# Altium schematic sheet Esp32_USB.SchDoc
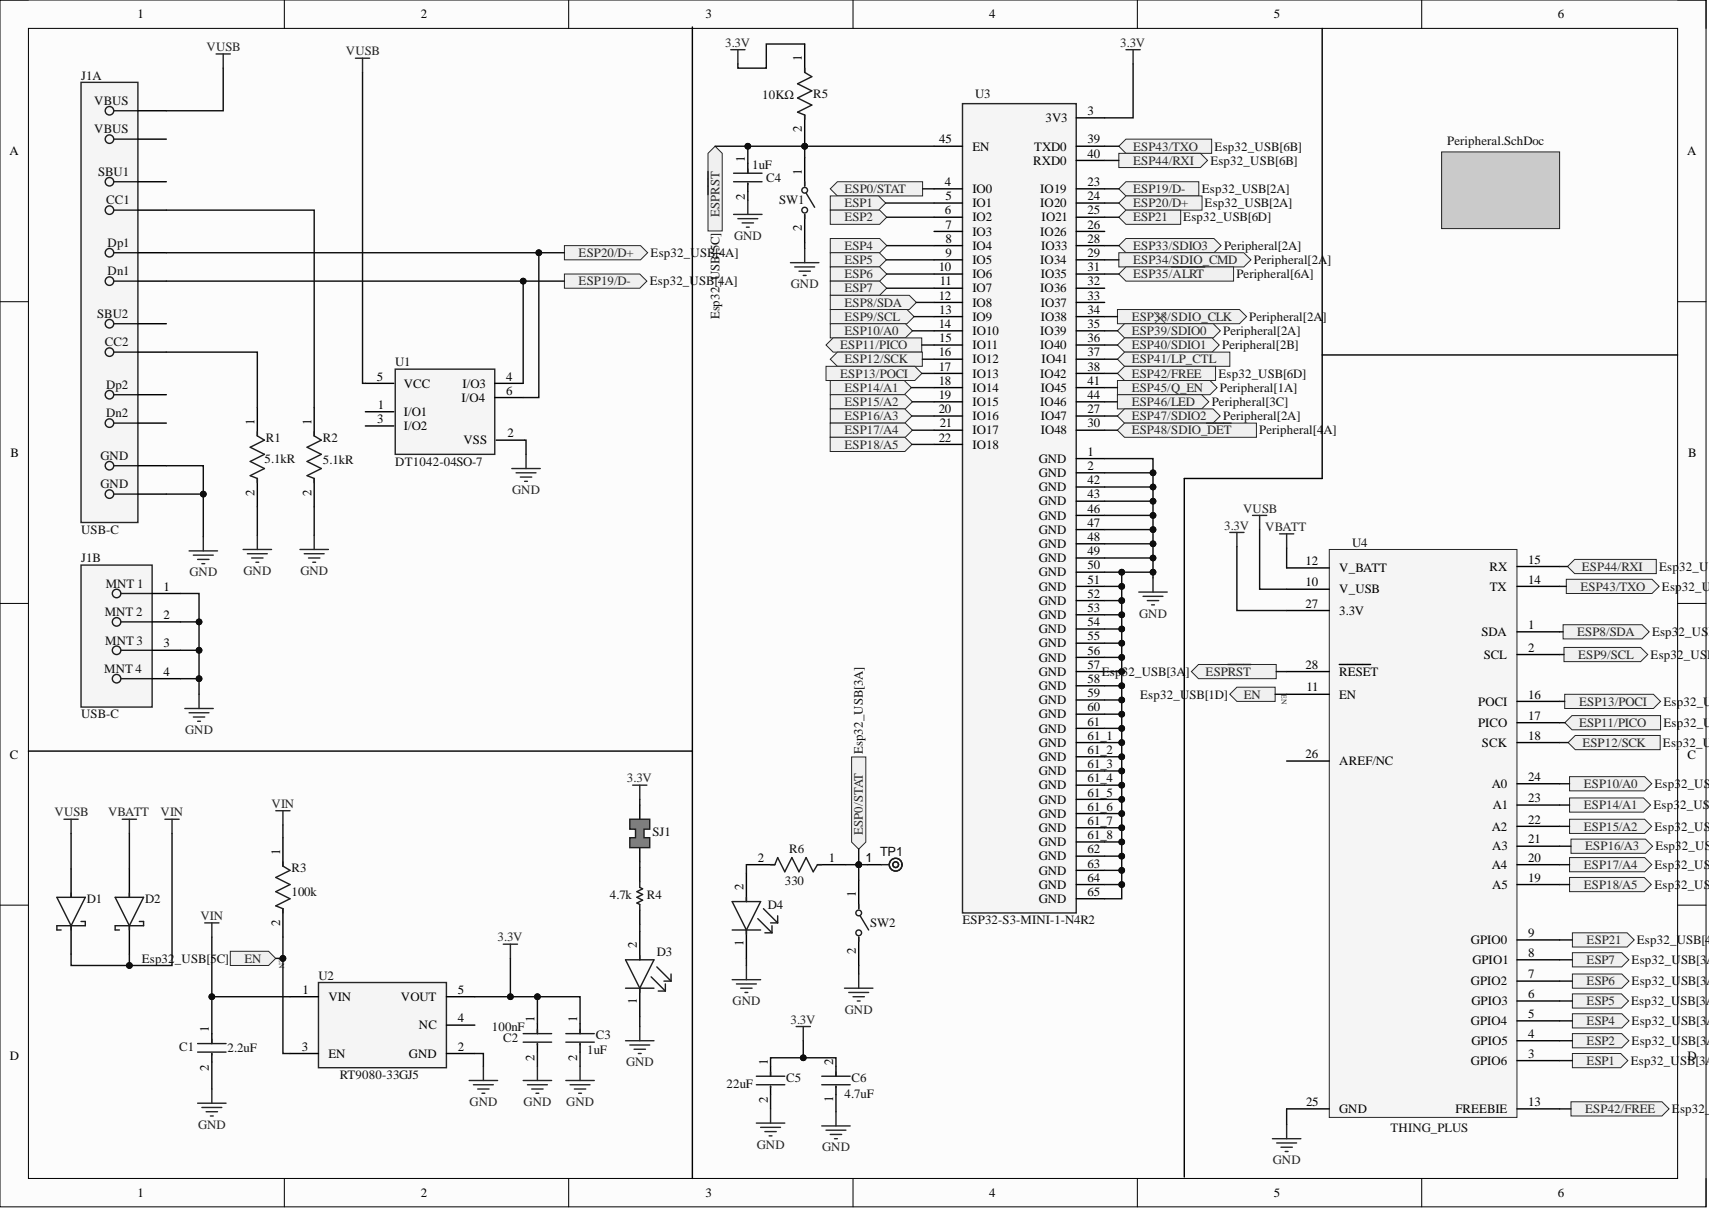
[diagram p0: Esp32_USB.SchDoc]

## Parts
C1  Capacitance: 2.2uF  [FP-GRM155-0_1-IPC_C]  (hidden comment: GRM155C81A225KE44D)
C2  Capacitance: 100nF  [FP-GRM033-0_03-IPC_B]  (hidden comment: GRM033C70J104KE14D)
C3  Capacitance: 1uF  [FP-GRM155-0_05-IPC_C]  (hidden comment: GRM155R61C105KA12D)
C4  Capacitance: 1uF  [FP-0402-L_1_0_0_05-W_0_5-IPC_B]  (hidden comment: EMK105BJ105KVHF)
C5  Capacitance: 22uF  [FP-0603-L_1_6_0_2-W_0_8_0-IPC_B]  (hidden comment: JMK107BC6226MA-T)
C6  Capacitance: 4.7uF  [FP-0402-L_1_0_0_15-W_0_5-IPC_C]  (hidden comment: JMK105BC6475MV-F)
D1  BAT60AE6327HTSA1  [FP-PG-SOD323-2-IPC_C]  (value not drawn)
D2  BAT60AE6327HTSA1  [FP-PG-SOD323-2-IPC_C]  (value not drawn)
D3  LTST-C191KRKT  [FP-LTST-C191KRKT-MFG]  (value not drawn)
D4  LTST-C194TGKT  [FP-LTST-C194TGKT-MFG]  (value not drawn)
J1  USB-C  [FP-2171790001-MFG]  (parts A,B)
R1  Resistance: 5.1kR  [FP-AC0402-IPC_C]  (hidden comment: AC0402JR-075K1L)
R2  Resistance: 5.1kR  [FP-AC0402-IPC_C]  (hidden comment: AC0402JR-075K1L)
R3  Resistance: 100k  [FP-CRCW0402-C-e3-MFG]  (hidden comment: CRCW0402100KFKEDC)
R4  Resistance: 4.7k  [RESC1005X40X25LL05T10]  (hidden comment: CRCW04024K70FKEDHP)
R5  value 10KΩ  Resistance: 10KΩ  [FP-RT0201-MFG]  (hidden comment: RT0201FRE0710KL)
R6  AC0603FR-07330RL  [FP-AC0603-MFG]  (value not drawn)
SJ1  Solder Jumper  [Solder Jumper-Footprint-1]  (value not drawn)
SW1  FSM2JART  [FP-FSM2JART-MFG]  (value not drawn)
SW2  FSM2JART  [FP-FSM2JART-MFG]  (value not drawn)
TP1  TPAD6R  [TP06R]  (value not drawn)  (no BOM)
U1  DT1042-04SO-7  [FP-SOT26_SC74R-MFG]
U2  RT9080-33GJ5  [FP-RT9080-33GJ5-IPC_C]
U3  ESP32-S3-MINI-1-N4R2  [XCVR_ESP32-S3-MINI-1-N4R2]
U4  THING_PLUS  (no BOM)

## Ports
EN, ESP0/STAT, ESP1, ESP2, ESP4, ESP5, ESP6, ESP7, ESP8/SDA, ESP9/SCL, ESP10/A0, ESP11/PICO, ESP12/SCK, ESP13/POCI, ESP14/A1, ESP15/A2, ESP16/A3, ESP17/A4, ESP18/A5, ESP19/D-, ESP20/D+, ESP21, ESP33/SDIO3, ESP34/SDIO_CMD, ESP35/~{ALRT}, ESP38/SDIO_CLK, ESP39/SDIO0, ESP40/SDIO1, ESP41/LP_CTL, ESP42/FREE, ESP43/TXO, ESP44/RXI, ESP45/Q_EN, ESP46/LED, ESP47/SDIO2, ESP48/SDIO_~{DET}, ESP~{RST}

## Nets
3.3V: C2.1 C3.1 C5.1 C6.2 R5.1 SJ1.1 U2.5(VOUT) U3.3(3V3) U4.27(3.3V)
GND: C1.2 C2.2 C3.2 C4.2 C5.2 C6.1 D3.1 D4.1 J1.1 J1.2 J1.3 J1.4 J1.A1-B12 J1.B1-A12 R1.2 R2.2 SW1.2 SW2.2 U1.2(VSS) U2.2(GND) U3.1(GND) U3.2(GND) U3.42(GND) U3.43(GND) U3.46(GND) U3.47(GND) U3.48(GND) U3.49(GND) U3.50(GND) U3.51(GND) U3.52(GND) U3.53(GND) U3.54(GND) U3.55(GND) U3.56(GND) U3.57(GND) U3.58(GND) U3.59(GND) U3.60(GND) U3.61(GND) U3.61_1(GND) U3.61_2(GND) U3.61_3(GND) U3.61_4(GND) U3.61_5(GND) U3.61_6(GND) U3.61_7(GND) U3.61_8(GND) U3.62(GND) U3.63(GND) U3.64(GND) U3.65(GND) U4.25(GND)
VBATT: D2.2 U4.12(V_BATT)
VIN: C1.1 D1.1 D2.1 R3.1 U2.1(VIN)
VUSB: D1.2 J1.A4-B9 U1.5(VCC) U4.10(V_USB)
EN: R3.2 U2.3(EN) U4.11(EN) ; port EN
ESP0/STAT: R6.1 SW2.1 TP1.1 U3.4(IO0) ; port ESP0/STAT
ESP1: U3.5(IO1) U4.3(GPIO6) ; port ESP1
ESP2: U3.6(IO2) U4.4(GPIO5) ; port ESP2
ESP4: U3.8(IO4) U4.5(GPIO4) ; port ESP4
ESP5: U3.9(IO5) U4.6(GPIO3) ; port ESP5
ESP6: U3.10(IO6) U4.7(GPIO2) ; port ESP6
ESP7: U3.11(IO7) U4.8(GPIO1) ; port ESP7
ESP8/SDA: U3.12(IO8) U4.1(SDA) ; port ESP8/SDA
ESP9/SCL: U3.13(IO9) U4.2(SCL) ; port ESP9/SCL
ESP10/A0: U3.14(IO10) U4.24(A0) ; port ESP10/A0
ESP11/PICO: U3.15(IO11) U4.17(PICO) ; port ESP11/PICO
ESP12/SCK: U3.16(IO12) U4.18(SCK) ; port ESP12/SCK
ESP13/POCI: U3.17(IO13) U4.16(POCI) ; port ESP13/POCI
ESP14/A1: U3.18(IO14) U4.23(A1) ; port ESP14/A1
ESP15/A2: U3.19(IO15) U4.22(A2) ; port ESP15/A2
ESP16/A3: U3.20(IO16) U4.21(A3) ; port ESP16/A3
ESP17/A4: U3.21(IO17) U4.20(A4) ; port ESP17/A4
ESP18/A5: U3.22(IO18) U4.19(A5) ; port ESP18/A5
ESP19/D-: J1.A7 U1.4(I/O3) U3.23(IO19) ; port ESP19/D-
ESP20/D+: J1.A6 U1.6(I/O4) U3.24(IO20) ; port ESP20/D+
ESP21: U3.25(IO21) U4.9(GPIO0) ; port ESP21
ESP33/SDIO3: U3.28(IO33) ; port ESP33/SDIO3
ESP34/SDIO_CMD: U3.29(IO34) ; port ESP34/SDIO_CMD
ESP35/~{ALRT}: U3.31(IO35) ; port ESP35/~{ALRT}
ESP38/SDIO_CLK: U3.34(IO38) ; port ESP38/SDIO_CLK
ESP39/SDIO0: U3.35(IO39) ; port ESP39/SDIO0
ESP40/SDIO1: U3.36(IO40) ; port ESP40/SDIO1
ESP41/LP_CTL: U3.37(IO41) ; port ESP41/LP_CTL
ESP42/FREE: U3.38(IO42) U4.13(FREEBIE) ; port ESP42/FREE
ESP43/TXO: U3.39(TXD0) U4.14(TX) ; port ESP43/TXO
ESP44/RXI: U3.40(RXD0) U4.15(RX) ; port ESP44/RXI
ESP45/Q_EN: U3.41(IO45) ; port ESP45/Q_EN
ESP46/LED: U3.44(IO46) ; port ESP46/LED
ESP47/SDIO2: U3.27(IO47) ; port ESP47/SDIO2
ESP48/SDIO_~{DET}: U3.30(IO48) ; port ESP48/SDIO_~{DET}
ESP~{RST}: C4.1 R5.2 SW1.1 U3.45(EN) U4.28(RESET) ; port ESP~{RST}
NetD3_2: D3.2 R4.2
NetD4_2: D4.2 R6.2
NetJ1_A5: J1.A5 R2.1
NetJ1_B5: J1.B5 R1.1
NetR4_1: R4.1 SJ1.2

Not connected: J1 A8 B4-A9 B6 B7 B8; U1 1(I/O1) 3(I/O2); U2 4(NC); U3 7(IO3) 26(IO26) 32(IO36) 33(IO37); U4 26(AREF/NC)

## Library data of the parts
C1 = CMP-06035-004415-1: Chip Multilayer Ceramic Capacitors for General Purpose, 0402, 2.2uF, X6S, 22%, 10%, 10V; Case Code (Imperial): 0402; Case Code (Metric): 1005; Case/Package: 0402; Component Kind: Standard; Dielectric: X6S; Height: 0.5mm; Length: 0.039inch; Library Name: Altium Content Vault; Max Operating Temperature: 105°C; Min Operating Temperature: -55°C; Mount: Surface Mount; Package Quantity: 10000; Packaging: Tape and Reel; Termination: SMD/SMT; Thickness: 0.022inch; Tolerance: 10%; Voltage Rating: 10V; Voltage Rating (DC): 10V; Weight: 0.000212oz; Width: 0.02inch
C2 = CMP-06035-000516-1: Chip Multilayer Ceramic Capacitors for General Purpose, 0201, 0.10uF, X7S, 22%, 10%, 6.3V; Component Kind: Standard; Dielectric: X7S; Length: 600um; Library Name: Altium Content Vault; Thickness: 300um; Tolerance: 10%; Voltage Rating (DC): 6.3V; Width: 300um
C3 = CMP-2008-04573-2: Chip Multilayer Ceramic Capacitors for General Purpose, 0402, 1.0uF, X5R, 15%, 10%, 16V; Case Code (Imperial): 0402; Case Code (Metric): 1005; Case/Package: 0402; Component Kind: Standard; Depth: 0.5mm; Dielectric: X5R; Height: 0.5mm; Lead Free: Lead Free; Length: 1mm; Library Name: Altium Content Vault; Material: Ceramic; Max Operating Temperature: 85°C; Min Operating Temperature: -55°C; Mount: Surface Mount; Packaging: Tape and Reel; Radiation Hardening: No; REACH SVHC: No SVHC; Thickness: 0.022inch; Tolerance: 10%; Voltage: 16V; Voltage Rating: 16V; Voltage Rating (DC): 16V; Width: 0.02inch
C4 = CMP-14477-000084-2: None; Dielectric: X5R; Tolerance: 10%; Voltage Rating: 16V; Case Code (Imperial): 0402; Case Code (Metric): 1005; Case/Package: 0402; Component Kind: Standard; Halogen Free: Halogen Free; Height: 0.5mm; Lead Free: Lead Free; Length: 0.039inch; Library Name: Altium Content Vault; Max Operating Temperature: 85°C; Min Operating Temperature: -55°C; Mount: Surface Mount; Package Quantity: 10000; Packaging: Tape and Reel; Ratings: AEC-Q200; RoHS Compliant: Yes; Termination: SMD/SMT; Thickness: 0.022inch; Voltage Rating (DC): 16V; Weight: 2.3E-05oz; Width: 0.5mm
C5 = CMP-14477-000021-3: Multilayer Ceramic Capacitor 22uF 6.3V X6S 20% SMD 0603 T/R; Case Code (Imperial): 0603; Case Code (Metric): 1608; Case/Package: 0603; Component Kind: Standard; Library Name: Altium Content Vault; Max Operating Temperature: 105°C; Min Operating Temperature: -55°C; Mount: Surface Mount; Packaging: Tape and Reel; RoHS Compliant: Yes; Tolerance: 20%; Voltage Rating: 6.3V
C6 = CMP-14477-001053-2: None; Tolerance: 20%; Voltage Rating: 6.3V; Case Code (Imperial): 0402; Case Code (Metric): 1005; Case/Package: 0402; Component Kind: Standard; Lead Free: Lead Free; Library Name: Altium Content Vault; Max Operating Temperature: 105°C; Min Operating Temperature: -55°C; Mount: Surface Mount; Packaging: Tape and Reel; RoHS Compliant: Yes
D1 D2 = CMP-32890-000004-1: DIODE SCHOTTKY 10V 3A SOD323-2; Element Configuration: Single; Forward Voltage: 370mV; Peak Non-Repetitive Surge Current: 5A; Polarity: Standard; Average Rectified Current: 3A; Case/Package: SOD-323-2; Component Kind: Standard; Contact Plating: Tin; Current Rating: 3A; Forward Current: 3A; Halogen Free: Not Halogen Free; Lead Free: Lead Free; Library Name: Altium Content Vault; Max Operating Temperature: 85°C; Max Repetitive Reverse Voltage (Vrrm): 10V; Max Reverse Voltage (DC): 10V; Min Operating Temperature: -55°C; Mount: Surface Mount; Number of Pins: 2; Output Current: 3A; Packaging: Tape and Reel; Radiation Hardening: No; REACH SVHC: No SVHC; Voltage Rating (DC): 10V
D3 = CMP-2000-05199-2: NexarPartId: 549908; Color: Red; Current: 2A; Dominant Wavelength: 631nm; Forward Current: 20mA; Forward Voltage: 2V; Lens Color: Colorless; Lens Style: Clear; Luminous Intensity: 54mcd; Number of Elements: 1; Power Dissipation: 75mW; Reverse Voltage: 5V; Viewing Angle: 130°; Wavelength: 639nm; Case Code (Imperial): 0603; Case Code (Metric): 1608; Case/Package: 0603; Component Kind: Standard; Depth: 800µm; Height: 550µm; Illumination Color: Red; Lead Free: Lead Free; Length: 1.6mm; Lens Transparency: Clear; Library Name: Altium Content Vault; Max Operating Temperature: 85°C; Min Operating Temperature: -55°C; Mount: Surface Mount; Number of LEDs: 1; Number of Pins: 2; Packaging: Tape and Reel; Power Rating: 75mW; Radiation Hardening: No; RoHS: Compliant; Schedule B: 8541402000, 8541402000; Test Current: 20mA; Voltage: 2V; Width: 800µm
D4 = CMP-22017-000031-1: LED GREEN CLEAR SMD; Dominant Wavelength: 525nm; Forward Current: 5mA; Forward Voltage: 3.2V; Lens Color: Colorless; Lens Style: Diffused; Luminous Intensity: 260.5mcd; Number of LEDs: 1; Test Current: 20mA; Viewing Angle: 130°; Case Code (Imperial): 0603; Case Code (Metric): 1608; Case/Package: 0603; Color: Green; Component Kind: Standard; Height: 0.35mm; Illumination Color: Green; Lead Free: Lead Free; Length: 1.6mm; Lens Transparency: Clear; Library Name: Altium Content Vault; Max Operating Temperature: 80°C; Min Operating Temperature: -20°C; Mount: Surface Mount; Number of Pins: 2; Package Quantity: 3000; Packaging: Tape and Reel; Radiation Hardening: No; Width: 0.8mm
J1 = CMP-04767-000028-2: CONN RCP USB2.0 TYP C 24P SMD RA; NexarPartId: 117190526; Current Rating: 1.25A; Gender: Receptacle; Number of Contacts: 16; Voltage Rating: 30V; Component Kind: Standard; Contact Material: Gold, Nickel; Height: 3.36mm; Library Name: Altium Content Vault; Max Operating Temperature: 85°C; Min Operating Temperature: -40°C; USB Standard: USB 2.0
R1 R2 = CMP-03412-038544-1: RES 5.1kΩ ±5% 0.063W 0402; Max Power Dissipation: 63mW; Power Rating: 62.5mW; Temperature Coefficient: 100ppm/°C; Voltage Rating: 50V; Case Code (Imperial): 0402; Case Code (Metric): 1005; Case/Package: 0402; Component Kind: Standard; Features: Moisture Resistant; Height: 0.015inch; Length: 0.039inch; Library Name: Altium Content Vault; Max Operating Temperature: 155°C; Min Operating Temperature: -55°C; Number of Terminations: 2; Packaging: Tape and Reel; Resistor Type: Thick Film; RoHS Compliant: Yes; Series: AC; Termination: SMD/SMT; Tolerance: 5%; Weight: 2.3E-05oz; Width: 0.5mm
R3 = CMP-02407-010951-1: RES 100K OHM 1% 1/16W 0402; Power Rating: 62.5mW; Temperature Coefficient: 100ppm/°C; Case/Package: SMD/SMT; Component Kind: Standard; Contact Plating: Tin; Lead Free: Lead Free; Length: 1mm; Library Name: Altium Content Vault; Max Operating Temperature: 155°C; Min Operating Temperature: -55°C; Mount: Surface Mount; Resistor Type: Thick Film; RoHS Compliant: Yes; Termination: Wraparound; Tolerance: 1%
R4 = CMP-2002-03050-1: Manufacturer: Vishay Dale; Component Kind: Standard; Library Name: Altium Content Vault; Part Number: CRCW04024K70FKEDHP
R5 = CMP-03412-013100-1: RES SMD 10K OHM 1% 1/20W 0201; Manufacturer: Yageo; Power Rating: 0.05W; Temperature Coefficient: 50 ppm/°C; Tolerance: 0.01; Voltage Rating: 25V; Automotive: No; Category: Res; Component Kind: Standard; ComponentLink2Description: Datasheet; ComponentLink2URL: http://www.yageo.com/documents/recent/PYu-RT_1-to-0.01_RoHS_L_9.pdf; Composition: Thin Film; Device Class L1: Passive Components; Device Class L2: Resistors; Device Class L3: Chip SMD Resistors; Digikey Description: RES SMD 10K OHM 1% 1/20W 0201; Footprint Url: http://www.yageo.com/exep/pages/download/literatures/PYu-R_Mount_10.pdf; Height: 0.26mm; Ipc Land Pattern Name: RESC6030X23; Lead Free: Yes; Library Name: Altium Content Vault; Manufacturer Part Number: RT0201FRE0710KL; Mouser Description: Res Thin Film 0201 10K Ohm 1% 1/20W ±50ppm/°C Molded SMD Paper T/R; Octopart: https://octopart.com/rt0201fre0710kl-yageo-71392129; Package: RT0201; RoHS: TRUE; Series: RT; Temperature: -55°C to +125°C; Temperature Range High: +125°C; Temperature Range Low: -55°C
R6 = CMP-03412-003137-2: RES 330Ω 1.00% 0.1W 0603; Max Power Dissipation: 100mW; Resistance: 330; Temperature Coefficient: 100ppm/°C; Tolerance: 1%; Voltage Rating: 50V; Case Code (Imperial): 0603; Case Code (Metric): 1608; Case/Package: 0603; Component Kind: Standard; Construction: Rectangular; Depth: 0.8mm; Features: Moisture Resistant; Height: 0.45mm; Length: 1.6mm; Library Name: Altium Content Vault; Max Operating Temperature: 155°C; Military Standard: Not; Min Operating Temperature: -55°C; Number of Terminations: 2; Packaging: Tape and Reel; Power Rating: 100mW; Ratings: AEC-Q200; Resistor Type: Thick Film; RoHS Compliant: Yes; Series: AC; Termination: SMD/SMT; Width: 0.8mm
SJ1 = CMP-00030-00001-3: 2 pad Solder Jumper; Case/Package: 1206; Component Kind: Standard; Max Operating Temperature: 155°C; Min Operating Temperature: -55°C; Mounting Technology: Surface Mount; Pins: 2; Library Name: Shuvendu Das
SW1 SW2 = CMP-03407-000009-1: SWITCH TACTILE SPST-NO 0.05A 12V; Actuator Length: 0.7mm; Actuator Material: Resin; Actuator Type: Standard; Contact Current Rating: 50mA; Contact Resistance: 100mR; Electrical Life: 100000Cycles; Illumination Type: Non-Illuminated; Operating Force: 260gf; Switch Function: Off-Mom; Throw Configuration: SPST; Component Kind: Standard; Contact Material: Copper Alloy; Contact Plating: Silver; Current Rating: 50mA; ELV: Compliant; Lead Free: Lead Free; Library Name: Altium Content Vault; Max Operating Temperature: 105°C; Max Voltage Rating (DC): 12V; Min Operating Temperature: -35°C; Mount: Through Hole; Orientation: Straight; Package Quantity: 1000; Packaging: Tape and Reel; REACH SVHC: No SVHC; RoHS Compliant: Yes; Series: FSMJRT; Termination: Solder; Type: Switch; Voltage Rating (DC): 12V
TP1 = CMP-00028-00002-2: 0.6mm round; Pins: 1; Library Name: Shuvendu Das
U1 = CMP-12828-000009-1: TVS DIODE 5V 9V SOT26; Manufacturer: Diodes Inc.; Breakdown Voltage: 6.2V; Capacitance: 0.65pF; Clamping Voltage: 6.3V; Direction: Unidirectional; Number Of Channels: 4; Peak Pulse Current: 6A; Peak Pulse Power: 55W; Standoff Height: 0.013mm; Value: 0.65pF; Automotive: No; Category: Diode; Component Kind: Standard; ComponentLink2Description: Datasheet; ComponentLink2URL: https://www.diodes.com/assets/Datasheets/DT1042-04SO.pdf; Device Class L1: Circuit Protection; Device Class L2: TVS Diodes; Device Class L3: unset; Digikey Description: TVS DIODE 5V 9V SOT26; Footprint Url: https://www.diodes.com/assets/Package-Files/SOT26-SC74R.pdf; Height: 1.4mm; Ipc Land Pattern Name: SOT95P280X140-6; Lead Free: Yes; Library Name: Altium Content Vault; Manufacturer Part Number: DT1042-04SO-7; Mouser Description: DT1042-04SO Series 6.2 V 6 A 4 Channel Low Capacitance TVS Diode Array - SOT-26; Octopart: https://octopart.com/dt1042-04so-7-diodes+inc.-30954914; Package: SOT26 (SC74R); Reverse Standoff Voltage: 5V; RoHS: TRUE; Temperature: -55°C to +85°C; Temperature Range High: +85°C; Temperature Range Low: -55°C
U2 = CMP-79890-000003-1: IC REG LIN 3.3V 600MA TSOT23-5; Dropout Voltage: 530mV; Max Input Voltage: 5.5V; Number of Regulators: 1; Output Configuration: Positive; Output Type: Fixed; Power Supply Rejection Ratio (PSRR): 75dB; Quiescent Current: 4uA; Case/Package: SOT-23-5; Component Kind: Standard; Library Name: Altium Content Vault; Max Operating Temperature: 85°C; Min Operating Temperature: -40°C; Min Output Voltage: 3.3V; Mount: Surface Mount; Output Current: 600mA; Packaging: Cut Tape; RoHS Compliant: Yes
U3 = ESP32-S3-MINI-1-N4R2: Bluetooth, WiFi 802.11b/g/n, Bluetooth v5.0 Transceiver Module 2.4GHz PCB Trace Surface Mount; MF: Espressif Systems; Check_prices: https://www.snapeda.com/parts/ESP32-S3-MINI-1-N4R2/Espressif+Systems/view-part/?ref=eda; Component Kind: Standard; Library Name: ESP32-S3-MINI-1-N4R2.IntLib; MP: ESP32-S3-MINI-1-N4R2; Package: None; SnapEDA_Link: https://www.snapeda.com/parts/ESP32-S3-MINI-1-N4R2/Espressif+Systems/view-part/?ref=snap; Supplier 1: DigiKey; Supplier Part Number 1: 1965-ESP32-S3-MINI-1-N4R2CT-ND
U4 = THING_PLUS: Library Name: Thing_plus.SchLib; Supplier 1: DigiKey; Supplier Part Number 1: 1568-14713-ND
